# Altium schematic sheet E08-GN02.SchDoc
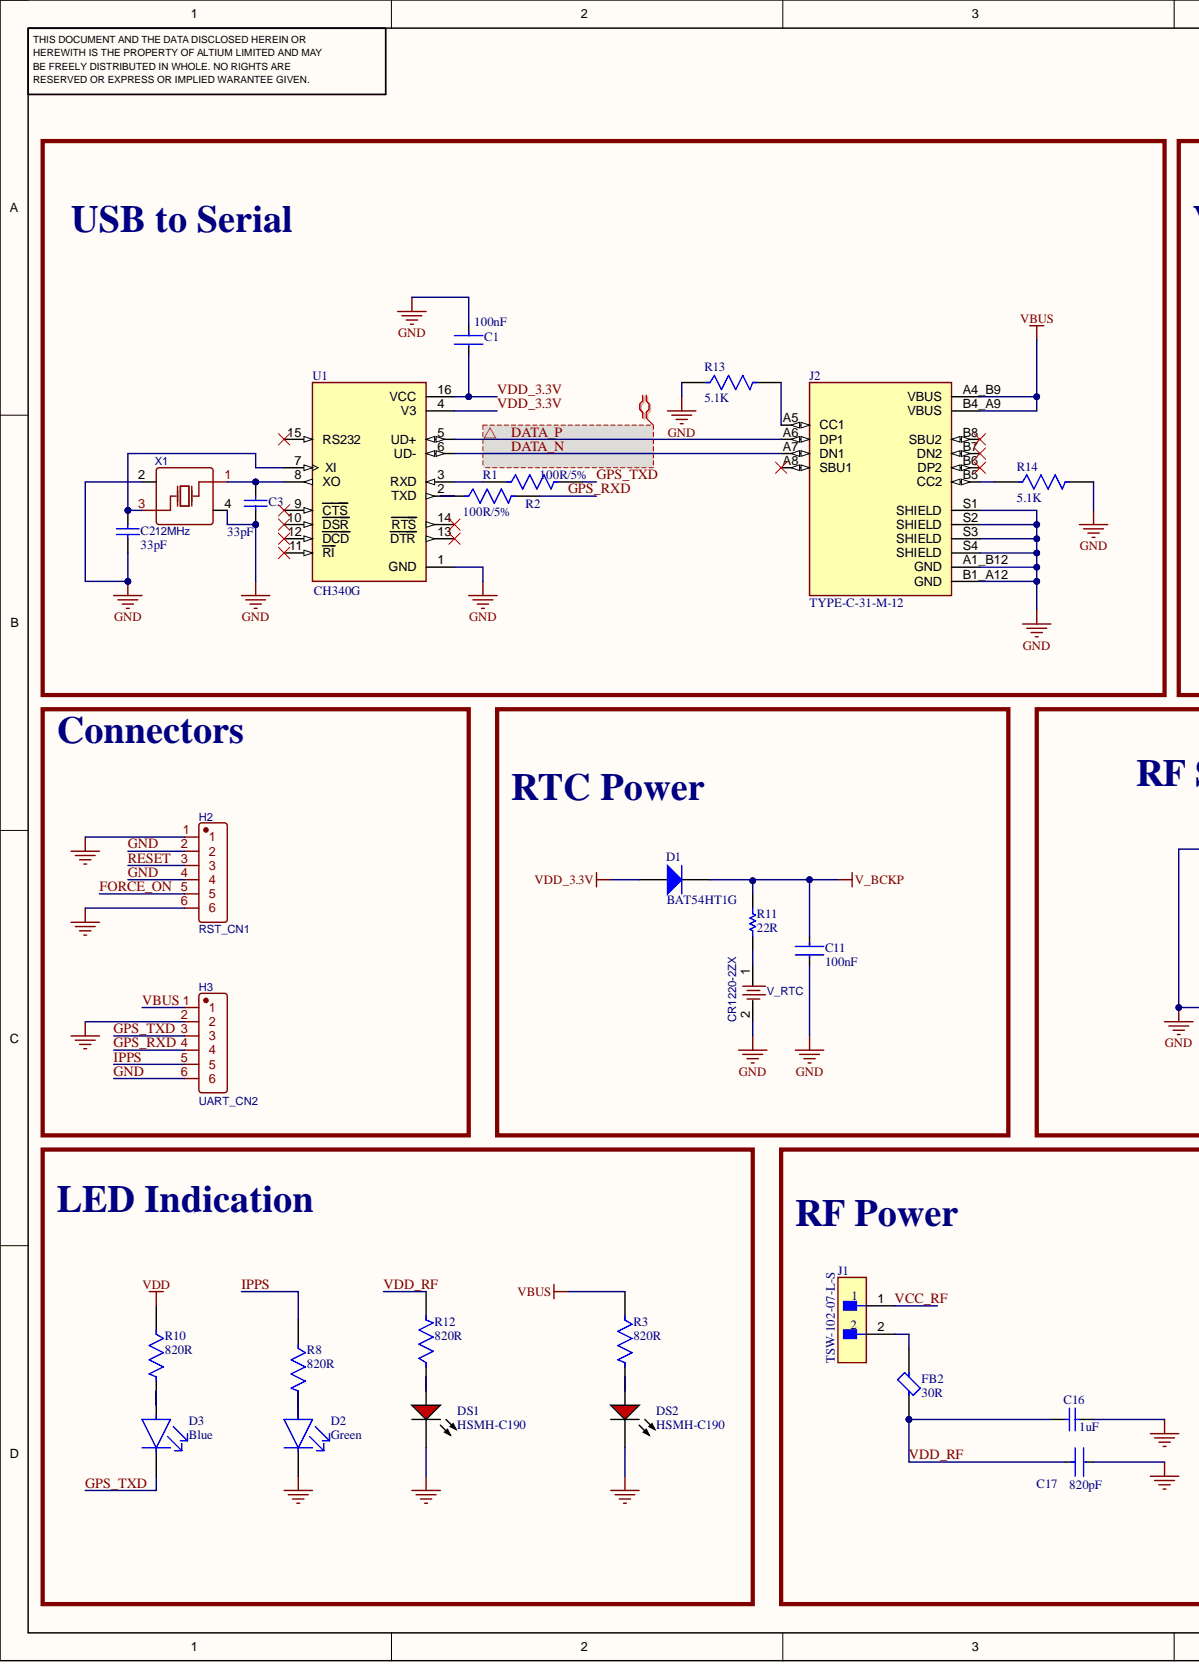
[diagram p0: E08-GN02.SchDoc, left]
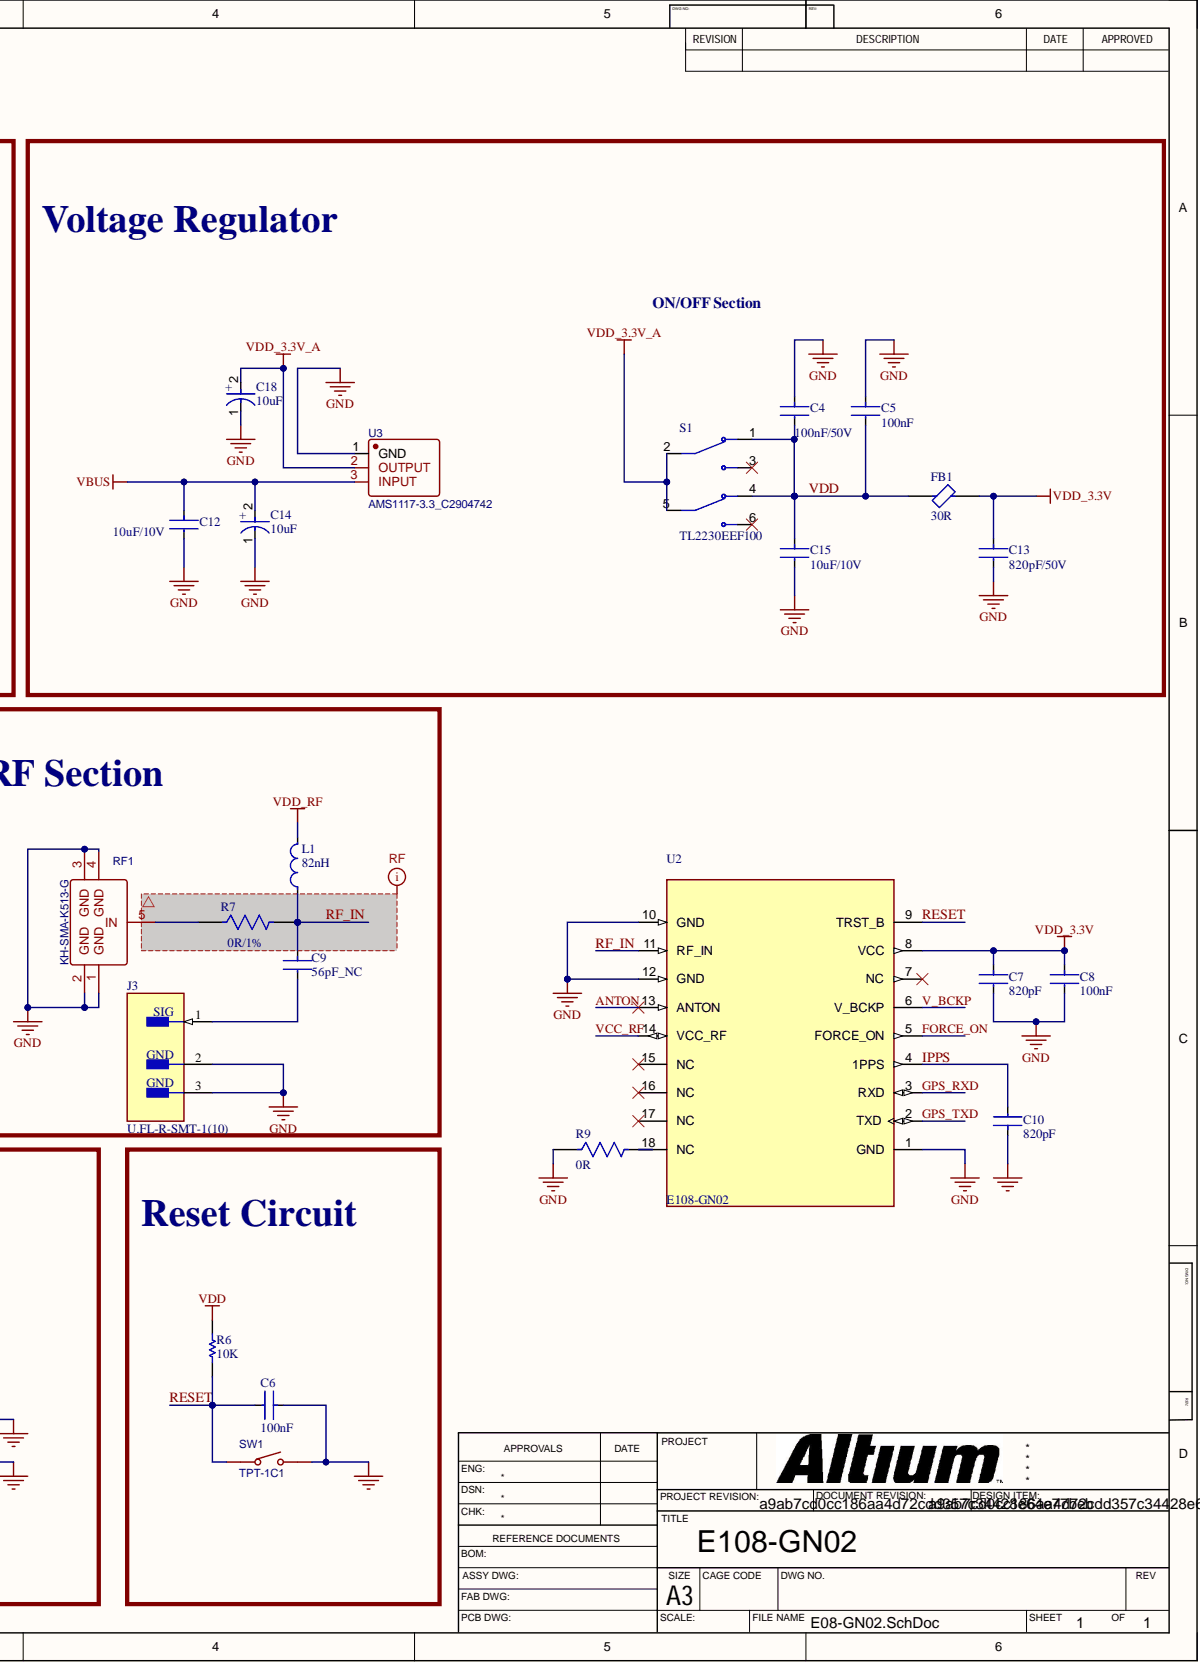
[diagram p1: E08-GN02.SchDoc, right]

## Parts
C1  100nF  [FP-CGA3E-0_3-0_1-IPC_A]
C2  33pF  [CAPC1608X92X38ML15T08]
C3  33pF  [CAPC1608X92X38ML15T08]
C4  100nF/50V  [FP-CGA3E-0_3-0_1-IPC_A]
C5  100nF  [FP-CGA3E-0_3-0_1-IPC_A]
C6  100nF  [FP-CGA3E-0_3-0_1-IPC_A]
C7  820pF  [FP-C0805C-DN-MFG]
C8  100nF  [FP-CGA3E-0_3-0_1-IPC_A]
C9  56pF_NC  [FP-C0805C-DN-MFG]
C10  820pF  [FP-C0805C-DN-MFG]
C11  100nF  [FP-C0805C-DN-MFG]
C12  10uF/10V  [FP-C0805C-DG-MFG]
C13  820pF/50V  [FP-C0805C-DN-MFG]
C14  10uF  [FP-TAJA-MFG]
C15  10uF/10V  [FP-C0805C-DG-MFG]
C16  1uF  [CAPC2013X135X45LL10T25]
C17  820pF  [FP-C0805C-DN-MFG]
C18  10uF  [FP-TAJA-MFG]
D1  BAT54HT1G  [ONSC-SOD-323-2-477-02_V]
D2  Green  [FP-LTST-C190GKT-MFG]
D3  Blue  [FP-LTST-C191TBKT-MFG]
DS1  HSMH-C190  [AVAG-HSMX-C190_V]
DS2  HSMH-C190  [AVAG-HSMX-C190_V]
FB1  30R  [FP-MMZ1608-0_8-IPC_A]
FB2  30R  [FP-MMZ1608-0_8-IPC_A]
H2  RST_CN1  [HDR-TH_6P-P2.54-V-M]
H3  UART_CN2  [HDR-TH_6P-P2.54-V-M]
J1  TSW-102-07-L-S  [FP-TSW-102-07-L-S-MFG]
J2  TYPE-C-31-M-12  [HRO_TYPE-C-31-M-12]
J3  U.FL-R-SMT-1(10)  [FP-U_FL-R-SMT-1_10-MFG]
L1  82nH  [FP-LQW18AN_00-MFG]
R1  100R/5%  [FP-CRCW0603-e3-IPC_B]
R2  100R/5%  [FP-CRCW0603-e3-IPC_B]
R3  820R  [FP-CRCW0805-e3-IPC_B]
R6  10K  [RESC2013X60X35NL10T20]
R7  0R/1%  [FP-RC0603-MFG]
R8  820R  [FP-CRCW0805-e3-IPC_B]
R9  0R  [FP-CRCW0805-e3-IPC_B]
R10  820R  [FP-CRCW0805-e3-IPC_B]
R11  22R  [RESC2013X70X40LL20T20]
R12  820R  [FP-CRCW0805-e3-IPC_B]
R13  5.1K  [FP-CRCW0603-e3-IPC_C]
R14  5.1K  [FP-CRCW0603-e3-IPC_C]
RF1  KH-SMA-K513-G  [ANT-TH_KH-SMA-K513-G]
S1  TL2230EEF100  [SW_TL2230EEF100]
SW1  TPT-1C1  [KEY-SMD_L3.0-W2.0-LS3.5]
U1  CH340G  [SOIC127P600X180-16N]
U2  E108-GN02  [E108-GN02]
U3  AMS1117-3.3_C2904742  [SOT-89-3_L4.5-W2.5-P1.50-LS4.1-BL]
V_RTC  CR1220-2ZX  [BAT-SMD_CR1220-2ZX]
X1  12MHz  [OSC-SMD_4P-L3.2-W2.5-BL]

## Nets
VDD_3.3V_A: C18.2 S1.2 S1.5 U3.2
ANTON: U2.13(ANTON)
DATA_N: J2.A7(DN1) U1.6(UD-)
DATA_P: J2.A6(DP1) U1.5(UD+)
FORCE_ON: H2.5 U2.5(FORCE_ON)
GND: C1.1 C2.1 C3.1 C4.1 C5.1 C6.1 C7.2 C8.2 C10.1 C11.2 C12.2 C13.2 C14.1 C15.2 C16.2 C17.2 C18.1 D2.1 DS1.2 DS2.2 H2.1 H2.2 H2.4 H2.6 H3.2 H3.6 J2.A1_B12(GND) J2.B1_A12(GND) J2.S1(SHIELD) J2.S2(SHIELD) J2.S3(SHIELD) J2.S4(SHIELD) J3.2 J3.3 R9.1 R13.1 R14.2 RF1.1(GND) RF1.2(GND) RF1.3(GND) RF1.4(GND) SW1.2 U1.1(GND) U2.1(GND) U2.10(GND) U2.12(GND) U3.1 V_RTC.2 X1.2 X1.4
GPS_RXD: H3.4 R2.2 U2.3(RXD)
GPS_TXD: D3.1 H3.3 R1.2 U2.2(TXD)
IPPS: C10.2 H3.5 R8.1 U2.4(1PPS)
RESET: C6.2 H2.3 R6.2 SW1.1 U2.9(TRST_B)
RF_IN: C9.1 L1.1 R7.2 U2.11(RF_IN)
V_BCKP: C11.1 D1.1 R11.2 U2.6(V_BCKP)
VBUS: C12.1 C14.2 H3.1 J2.A4_B9(VBUS) J2.B4_A9(VBUS) R3.1 U3.3
VCC_RF: J1.1 U2.14(VCC_RF)
VDD: C4.2 C5.2 C15.1 FB1.1 R6.1 R10.1 S1.1 S1.4
VDD_3.3V: C1.2 C7.1 C8.1 C13.1 D1.2 FB1.2 U1.4(V3) U1.16(VCC) U2.8(VCC)
VDD_RF: C16.1 C17.1 FB2.2 L1.2 R12.2
NetC2_2: C2.2 U1.7(XI) X1.3
NetC3_2: C3.2 U1.8(XO) X1.1
NetC9_2: C9.2 J3.1
NetD2_2: D2.2 R8.2
NetD3_2: D3.2 R10.2
NetDS1_1: DS1.1 R12.1
NetDS2_1: DS2.1 R3.2
NetFB2_1: FB2.1 J1.2
NetJ2_A5: J2.A5(CC1) R13.2
NetJ2_B5: J2.B5(CC2) R14.1
NetR1_1: R1.1 U1.3(RXD)
NetR2_1: R2.1 U1.2(TXD)
NetR7_1: R7.1 RF1.5(IN)
NetR9_2: R9.2 U2.18(NC)
NetR11_1: R11.1 V_RTC.1

Not connected: J2 A8(SBU1) B6(DP2) B7(DN2) B8(SBU2); S1 3 6; U1 9(CTS) 10(DSR) 11(RI) 12(DCD) 13(DTR) 14(RTS) 15(RS232); U2 7(NC) 15(NC) 16(NC) 17(NC)

## Library data of the parts
C1 C4-C6 C8 = CMP-2000-07546-2: Cap Ceramic 100nF 50V X7R 10% Pad SMD 0603 +125°C Automotive T/R; Manufacturer: YAGEO; Manufacturer Part Number: CC0603KRX7R9BB104; Capacitance: 100nF; Case Code (Imperial): 0603; Case Code (Metric): 1608; Case/Package: 0603; Component Kind: Standard; Composition: Ceramic; Depth: 0.8mm; Dielectric: X7R; Height: 0.8mm; LCSC Part: C14663; Lead Free: Lead Free; Length: 1.6mm; Library Name: Altium Content Vault; Max Operating Temperature: 125°C; Min Operating Temperature: -55°C; Mount: Surface Mount; Package Quantity: 1; Packaging: Tape and Reel; Radiation Hardening: No; Ratings: AEC-Q200; REACH SVHC: No SVHC; Termination: SMD/SMT; Thickness: 0.035inch; Tolerance: 10%; Voltage: 50V; Voltage Rating: 50V; Voltage Rating (DC): 50V; Weight: 7.1E-05oz; Width: 0.8mm
C2 C3 = CMP-2006-01513-1: Manufacturer: Samsung Electro-Mechanics; Manufacturer Part Number: CL10C330JB8NNNC; Part Number: VJ0603D330JXPAJ; Component Kind: Standard; LCSC Part: C1663; Library Name: Altium Content Vault
C7 C10 C13 C17 = CMP-2007-00457-2: CAP CER 820PF 50V 5% NP0 0805; Manufacturer: YAGEO; Manufacturer Part Number: CC0805KRX7R0BB821; Material: Ceramic; Temperature Characteristic: C0G; Temperature Coefficient: 30ppm/°C; Tolerance: 0.05; Automotive: No; Capacitance: 820 pF; Category: Cap; Component Kind: Standard; ComponentLink2Description: Datasheet; ComponentLink2URL: https://content.kemet.com/datasheets/KEM_C1003_C0G_SMD.pdf; Device Class L1: Passive Components; Device Class L2: Capacitors; Device Class L3: Ceramic Capacitors; Digikey Description: CAP CER 820PF 50V 5% NP0 0805; Height: 0.88mm; Ipc Land Pattern Name: CAPC200125X78; LCSC Part: C577015; Lead Free: Yes; Library Name: Altium Content Vault; Mouser Description: Multilayer Ceramic Capacitors MLCC - SMD/SMT 50volts 820pF C0G 5%; Octopart: https://octopart.com/c0805c821j5gactu-kemet-3873926; Package: C0805C-DN; RoHS: TRUE; Temperature: -55°C to +125°C; Temperature Range High: +125°C; Temperature Range Low: -55°C; Value: 820 pF; Voltage Rating: 50 V
C9 = CMP-2007-00050-2: CAP CER 56PF 50V C0G/NP0 0805; Manufacturer: YAGEO; Manufacturer Part Number: CC0805FRNPO9BN560; Material: Ceramic; Temperature Characteristic: C0G; Temperature Coefficient: 30ppm/°C; Tolerance: 0.05; Automotive: No; Capacitance: 56 pF; Category: Cap; Component Kind: Standard; ComponentLink2Description: Datasheet; ComponentLink2URL: https://content.kemet.com/datasheets/KEM_C1003_C0G_SMD.pdf; Device Class L1: Passive Components; Device Class L2: Capacitors; Device Class L3: Ceramic Capacitors; Digikey Description: CAP CER 56PF 50V C0G/NP0 0805; Height: 0.88mm; Ipc Land Pattern Name: CAPC200125X78; LCSC Part: NC-C527187; Lead Free: Yes; Library Name: Altium Content Vault; Mouser Description: 0805 C 56pF Ceramic Multilayer Capacitor, 50 VDC, +125degC, C0G Dielec, +/-5%; Octopart: https://octopart.com/c0805c560j5gactu-kemet-93783; Package: C0805C-DN; RoHS: TRUE; Temperature: -55°C to +125°C; Temperature Range High: +125°C; Temperature Range Low: -55°C; Value: 56 pF; Voltage Rating: 50 V
C11 = CMP-2007-00279-2: CAP CER 0.1UF 50V X7R 0805; Manufacturer: YAGEO; Manufacturer Part Number: CC0805KRX7R9BB104; Capacitance: 0.10 μF; Material: Ceramic; Temperature Coefficient: 30ppm/°C; Tolerance: 0.1; Value: 0.10 μF; Automotive: No; Category: Cap; Component Kind: Standard; ComponentLink2Description: Datasheet; ComponentLink2URL: https://content.kemet.com/datasheets/KEM_C1003_C0G_SMD.pdf; Device Class L1: Passive Components; Device Class L2: Capacitors; Device Class L3: Ceramic Capacitors; Digikey Description: CAP CER 0.1UF 50V X7R 0805; Height: 0.88mm; Ipc Land Pattern Name: CAPC200125X78; LCSC Part: C49678; Lead Free: Yes; Library Name: Altium Content Vault; Mouser Description: Cap Ceramic 0.1uF 50V X7R 10% SMD 2.0 x 1.2 mm 125 C Paper T/R; Octopart: https://octopart.com/c0805c104k5ractu-kemet-143926; Package: C0805C-DN; RoHS: TRUE; Temperature: -55°C to +125°C; Temperature Characteristic: X7R; Temperature Range High: +125°C; Temperature Range Low: -55°C; Voltage Rating: 50 V
C12 C15 = CMP-2007-03243-2: CAP CER 10UF 10V X5R 0805; 3DS Part Number: 220-00001; Manufacturer: YAGEO; Manufacturer Part Number: CC0805KKX5R6BB106; Material: Ceramic; Temperature Coefficient: 15%; Tolerance: 10%; Automotive: No; Capacitance: 10uF; Category: Cap; Component Kind: Standard; ComponentLink2Description: Datasheet; ComponentLink2URL: https://content.kemet.com/datasheets/KEM_C1006_X5R_SMD.pdf; Device Class L1: Passive Components; Device Class L2: Capacitors; Device Class L3: Ceramic Capacitors; Digikey Description: CAP CER 10UF 10V X5R 0805; Height: 1.4mm; Ipc Land Pattern Name: CAPC200125X125; LCSC Part: C89832; Lead Free: Yes; Library Name: Altium Content Vault; Mouser Description: CAP CER 10UF 10V X5R 0805; Octopart: https://octopart.com/c0805c106k8pactu-kemet-98697; Package: C0805C-DG; RoHS: TRUE; Temperature: -55°C to +85°C; Temperature Characteristic: X5R; Temperature Range High: +85°C; Temperature Range Low: -55°C; Value: 10uF; Voltage: 10V; Voltage Rating: 10V
C14 C18 = CMP-2000-07217-2: 10uF 10V  ±10% 3Ω 1206  SMD Tantalum Capacitor; Dissipation Factor: 6%; ESR (Equivalent Series Resistance): 3R; Leakage Current: 1uA; Polarity: Polar; Q Factor: 6; Capacitance: 10uF; Case Code (Imperial): 1206; Case Code (Metric): 3216; Case/Package: 1206; Component Kind: Standard; Construction: Flat; Depth: 1.6mm; Dielectric Material: Tantalum; Features: General Purpose; Height: 1.6mm; Height - Seated (Max): 0.071inch; LCSC Part: C7171; Lead Free: Lead Free; Length: 3.2mm; Library Name: Altium Content Vault; Max Operating Temperature: 125°C; Min Operating Temperature: -55°C; Mount: Surface Mount; Number of Pins: 2; Package Quantity: 1; Packaging: Tape and Reel; Radiation Hardening: No; REACH SVHC: No SVHC; RoHS Compliant: Yes; Series: TAJ; Termination: SMD/SMT; Tolerance: 10%; Voltage: 10V; Voltage Rating: 10V; Voltage Rating (DC): 10V; Width: 1.6mm
C16 = CMP-1036-04761-2: Manufacturer: Samsung Electro-Mechanics; Manufacturer Part Number: CL21B105KBFNNNE; Part Number: GRM21BR71H105KA12L; Component Kind: Standard; LCSC Part: C28323; Library Name: Altium Content Vault
D1 = CMP-1055-00462-1: Schottky Barrier Diode, 2-Pin SOD-323, Pb-Free, Tape and Reel; Manufacturer: onsemi; Configuration: Single; IFSM Max (A): 0.6; trr Max (ns): 5; Component Kind: Standard; ComponentLink1Description: Manufacturer URL; ComponentLink1URL: http://www.onsemi.com/; ComponentLink2Description: Datasheet; ComponentLink2URL: http://www.onsemi.cn/pub_link/Collateral/BAT54HT1-D.PDF; ComponentLink3Description: Package Specification; ComponentLink3URL: http://www.onsemi.com/pub_link/Collateral/477-02.PDF.1; DatasheetVersion: Rev. 6; LCSC Part: C21107; Library Name: Altium Content Vault; Manufacturer Part Number: BAT54HT1G; Mounting Technology: Surface Mount; PackageDescription: 2-Pin SOD, Body 1.7 x 1.25 mm; PackageReference: SOD-323-2-477-02; PackageVersion: Rev. H, 03/2007; Packing: Tape and Reel; PartNumber: BAT54HT1G; RoHS: TRUE
D2 = CMP-22017-000005-2: LED GREEN CLEAR CHIP SMD; Manufacturer: Everlight Elec; Manufacturer Part Number: 19-217/GHC-YR1S2/3T; Dominant Wavelength: 569nm; Forward Current: 30mA; Forward Voltage: 2.1V; Lens Color: Colorless; Luminous Intensity: 6mcd; Number of Elements: 1; Power Dissipation: 100mW; Reverse Voltage: 5V; Test Current: 10mA; Viewing Angle: 130°; Voltage: 2V; Wavelength: 565nm; Case Code (Imperial): 0603; Case Code (Metric): 1608; Case/Package: 0603; Color: Green; Component Kind: Standard; Depth: 0.8mm; Height: 0.8mm; Illumination Color: Green; LCSC Part: C72043; Lead Free: Lead Free; Length: 1.6mm; Lens Style: Clear; Lens Transparency: Clear; Library Name: Altium Content Vault; Max Operating Temperature: 85°C; Min Operating Temperature: -55°C; Mount: Surface Mount; Number of LEDs: 1; Number of Pins: 2; Package Quantity: 1; Packaging: Tape and Reel; Power Rating: 100mW; Radiation Hardening: No; Width: 0.8mm
D3 = CMP-22017-000008-1: LED BLUE CLEAR CHIP SMD; Manufacturer Part Number: Everlight Elec; Dominant Wavelength: 475nm; Forward Current: 20mA; Forward Voltage: 3.8V; Lens Color: Colorless; Lens Style: Diffused; Luminous Intensity: 180mcd; Max Power Dissipation: 75mW; Number of Elements: 1; Power Dissipation: 76mW; Reverse Voltage: 5V; Viewing Angle: 130°; Wavelength: 468nm; Case Code (Imperial): 0603; Case Code (Metric): 1608; Case/Package: 0603; Color: Blue; Component Kind: Standard; Depth: 1.6mm; Height: 0.55mm; Illumination Color: Blue; LCSC Part: C72041; Lead Free: Lead Free; Length: 0.8mm; Lens Transparency: Clear; Library Name: Altium Content Vault; Max Operating Temperature: 80°C; Min Operating Temperature: -20°C; Mount: Surface Mount; Number of LEDs: 1; Number of Pins: 2; Package Quantity: 1; Packaging: Tape and Reel; Radiation Hardening: No; Test Current: 20mA; Width: 0.8mm
DS1 = CMP-1744-00004-1: LED Uni-Color Red, 65 mW, 20 mA, -40 to 85 degC, 2-Pin SMD, RoHS, Tape and Reel; Manufacturer: Hubei KENTO Elec; Manufacturer Part Number: KT-0603R; Connector Type: USB; Current Rating: 1 A; Gender: Male; Component Kind: Standard; ComponentLink1Description: Manufacturer URL; ComponentLink1URL: http://www.avagotech.com/; ComponentLink2Description: Datasheet; ComponentLink2URL: http://docs.avagotech.com/docs/AV02-0551EN; ComponentLink3Description: Package Specification; ComponentLink3URL: http://www.fairchildsemi.com/dwg/MA/MA03D.pdf; Contact Material: Copper; Contact Plating: Gold; DatasheetVersion: Mar-12; Height: 2.8 mm; LCSC Part: C2286; Lead Pitch: 0.65 mm; Length: 7.5 mm; Library Name: Altium Content Vault; Max Operating Temperature: 85 degC; Min Operating Temperature: -30 degC; Mount: Surface Mount; Package Quantity: 2000; PackageDescription: 2-Pin Surface Mount Device, Body 1.6 x 0.8 mm, Height 0.9 mm; PackageReference: HSMX-C190; Packaging: Tape and Reel; Pins: 5; REACH SVHC: No SVHC; RoHSCompliant: true; Width: 5.6 mm
DS2 = CMP-1744-00004-1: LED Uni-Color Red, 65 mW, 20 mA, -40 to 85 degC, 2-Pin SMD, RoHS, Tape and Reel; Connector Type: USB; Current Rating: 1 A; Gender: Male; Component Kind: Standard; ComponentLink1Description: Manufacturer URL; ComponentLink1URL: http://www.avagotech.com/; ComponentLink2Description: Datasheet; ComponentLink2URL: http://docs.avagotech.com/docs/AV02-0551EN; ComponentLink3Description: Package Specification; ComponentLink3URL: http://www.fairchildsemi.com/dwg/MA/MA03D.pdf; Contact Material: Copper; Contact Plating: Gold; DatasheetVersion: Mar-12; Height: 2.8 mm; Lead Pitch: 0.65 mm; Length: 7.5 mm; Library Name: Altium Content Vault; Max Operating Temperature: 85 degC; Min Operating Temperature: -30 degC; Mount: Surface Mount; Package Quantity: 2000; PackageDescription: 2-Pin Surface Mount Device, Body 1.6 x 0.8 mm, Height 0.9 mm; PackageReference: HSMX-C190; Packaging: Tape and Reel; Pins: 5; REACH SVHC: No SVHC; RoHSCompliant: true; Width: 5.6 mm
FB1 FB2 = CMP-08257-000413-1: Signal Line Ferrite Bead 30Ω  @ 100MHz 1500mA DCR 50mΩ SMD 0603; Manufacturer: TAI-TECH; Manufacturer Part Number: HCB1608KF-600T30; Current Rating: 1.5A; DC Resistance (DCR): 50mR; Tolerance: 25%; Case Code (Imperial): 0603; Case Code (Metric): 1608; Case/Package: 0603; Component Kind: Standard; Core Material: Ferrite; Height: 0.037inch; Impedance: 30R; LCSC Part: C304317; Lead Free: Lead Free; Length: 0.063inch; Library Name: Altium Content Vault; Max DC Current: 1.5A; Mount: Surface Mount; Package Quantity: 1; Packaging: Cut Tape; REACH SVHC: No SVHC; RoHS Compliant: Yes; Series: MMZ; Width: 0.031inch
H2 H3 = KH-A2541WV-6P: BOM_Manufacturer: kinghelm(金航标); Contributor: LCSC; BOM_JLCPCB Part Class: Extended Part; BOM_Manufacturer Part: KH-A2541WV-6P; BOM_Supplier: LCSC; BOM_Supplier Part: C2833331; Component Kind: Standard; Library Name: E108-GN02.SchLib; spicePre: H; spiceSymbolName: KH-A2541WV-6P
J1 = CMP-02766-001428-1: CONN HEADER VERT 2POS 2.54MM; Manufacturer: ZHOURI; Manufacturer Part Number: 2.54-1*2; Connector Type: Male Pin; Current Rating: 7.6A; Gender: Male; Max Voltage Rating (AC): 550V; Number of Contacts: 2; Color: Black; Component Kind: Standard; Contact Material: Bronze; Contact Mating Length: 0.23inch; Contact Plating: Gold; Contact Type: Male Pin; Depth: 2.54mm; Housing Color: Black; Housing Material: Polyester; LCSC Part: NC-C5116481; Lead Free: Lead Free; Length: 5.08mm; Library Name: Altium Content Vault; Max Current Rating: 7.6A; Max Operating Temperature: 125°C; Min Operating Temperature: -55°C; Mount: Through Hole; Number of Positions: 2; Number of Rows: 1; Orientation: Straight; Package Quantity: 1; Packaging: Bulk; Pitch: 2.54mm; Radiation Hardening: No; REACH SVHC: No SVHC; RoHS Compliant: Yes; Series: TSW; Termination: Solder; Voltage Rating: 550V; Width: 2.54mm
J2 = TYPE-C-31-M-12: Manufacturer: Korean Hroparts Elec; MF: {'manufacturer': u'HRO Electronics Co., Ltd.'}; Availability: Unavailable; Component Kind: Standard; LCSC Part: C165948; Manufacturer Part Number: TYPE-C-31-M-12; MP: TYPE-C-31-M-12; Package: None; Price: None
J3 = CMP-2000-05152-3: CONN U.FL RCPT STR 50 OHM SMD; Manufacturer: HRS(Hirose); Body Material: Bronze; Body Plating: Silver; Connector Type: Coaxial; Dielectric Material: Polymer; Frequency: 6GHz; Gender: Male; Impedance: 50R; Insulation Resistance: 500MR; Component Kind: Standard; Contact Material: Brass; Contact Plating: Gold; Depth: 3.1mm; Fastening Type: Snap; Housing Color: Beige; LCSC Part: C88373; Lead Free: Lead Free; Length: 3mm; Library Name: Altium Content Vault; Manufacturer Part Number: U.FL-R-SMT-1(10); Max Frequency: 6GHz; Max Operating Temperature: 90°C; Min Operating Temperature: -40°C; Mount: Surface Mount; Orientation: Straight; Package Quantity: 2500; Packaging: Cut Tape; Radiation Hardening: No; REACH SVHC: No SVHC; Series: U.FL; Termination: Solder; Weight: 0.001764oz; Wire/Cable Type: Coaxial
L1 = CMP-06042-011367-1: Wire Wound RF Inductor 82nH ±2% 250mA 0.6Ω 0603 (1608); Manufacturer: Sunltech Tech; Manufacturer Part Number: SCC1608C82NJSP; Core Material: Non-Magnetic; Current: 550mA; DC Resistance (DCR): 600mR; Q Factor: 34; Self Resonant Frequency: 2GHz; Shielding: Unshielded; Test Frequency: 100MHz; Case Code (Imperial): 0603; Case Code (Metric): 1608; Case/Package: 0603; Component Kind: Standard; Current Rating: 250mA; DC Current: 250mA; Depth: 1mm; Height: 0.8mm; Height - Seated (Max): 0.039inch; Inductance: 82nH; Inductance Tolerance: 2%; LCSC Part: C412043; Lead Free: Lead Free; Length: 1.6mm; Library Name: Altium Content Vault; Max DC Current: 250mA; Max Operating Temperature: 125°C; Military Standard: Not; Min Operating Temperature: -55°C; Mount: Surface Mount; Package Quantity: 4000; Packaging: Tape and Reel; REACH SVHC: No SVHC; Resistor Type: Wirewound; Series Resistance: 600mR; Termination: SMD/SMT; Tolerance: 2%; Weight: 0.000106oz; Width: 0.8mm
R1 R2 = CMP-2000-03827-2: RES Thick Film, 100Ω, 5%, 0.1W, 200ppm/°C, 0603; Manufacturer: FOJAN; Manufacturer Part Number: FRC0603J101 TS; Max Power Dissipation: 100mW; Resistance: 100R; Voltage Rating: 75V; Case Code (Imperial): 0603; Case Code (Metric): 1608; Case/Package: 0603; Component Kind: Standard; Composition: Thick Film; Contact Plating: Tin; Depth: 0.85mm; Features: Automotive AEC-Q200; Height: 0.02inch; LCSC Part: C2907089; Length: 1.55mm; Library Name: Altium Content Vault; Max Operating Temperature: 155°C; Military Standard: Not; Min Operating Temperature: -55°C; Number of Terminations: 2; Package Quantity: 1; Packaging: Tape and Reel; Power Rating: 100mW; Radiation Hardening: No; Temperature Coefficient: 200ppm/°C; Termination: SMD/SMT; Tolerance: 5%; Weight: 7.1E-05oz; Width: 0.85mm
R3 R10 R12 = CMP-2001-04130-2: RES Thick Film, 820Ω, 1%, 0.125W, 100ppm/°C, 0805; Manufacturer: UNI-ROYAL(Uniroyal Elec); Manufacturer Part Number: 0805W8F8200T5E; Max Power Dissipation: 125mW; Voltage Rating: 150V; Case Code (Imperial): 0805; Case Code (Metric): 2012; Case/Package: 0805; Component Kind: Standard; Composition: Thick Film; Contact Plating: Tin; Depth: 1.25mm; Features: Automotive AEC-Q200; Height: 0.45mm; LCSC Part: C17837; Lead Free: Lead Free; Length: 2mm; Library Name: Altium Content Vault; Max Operating Temperature: 155°C; Military Standard: Not; Min Operating Temperature: -55°C; Number of Terminations: 2; Package Quantity: 10; Packaging: Tape and Reel; Power Rating: 125mW; Radiation Hardening: No; Resistance: 820R; Temperature Coefficient: 100ppm/°C; Termination: SMD/SMT; Tolerance: 1%; Voltage Rating (DC): 150V; Weight: 0.000194oz; Width: 1.25mm
R6 = CMP-1659-00013-4: Chip Resistor, 10 KOhm, +/- 1%, 0.125 W, -55 to 155 degC, 0805 (2012 Metric), RoHS, Tape and Reel; Manufacturer: UNI-ROYAL(Uniroyal Elec); Manufacturer Part Number: 0805W8F1002T5E; Voltage Rating: 150 V; Component Kind: Standard; ComponentLink1Description: Manufacturer URL; ComponentLink1URL: http://www.yageo.com/; ComponentLink2Description: Datasheet; ComponentLink2URL: http://www.yageo.com/documents/recent/PYu-RC_Group_51_RoHS_L_04.pdf; DatasheetVersion: Rev. 4, 02/2016; Height: 0.5 mm; LCSC Part: C17414; Length: 2 mm; Library Name: Altium Content Vault; Max Operating Temperature: 155 degC; Min Operating Temperature: -55 degC; Mount: Surface Mount; Mounting Technology: Surface Mount; Package Quantity: 5000; PackageDescription: 2-Pin Surface Mounted Device, Body 2 x 1.25 mm; PackageReference: 0805; Packaging: Tape and Reel; Pins: 2; REACH SVHC: No SVHC; RoHSCompliant: true
R7 = CMP-2000-06925-2: RES SMD 0 OHM JUMPER 1/10W 0603; Manufacturer: UNI-ROYAL(Uniroyal Elec); Manufacturer Part Number: 0603WAF0000T5E; Power Rating: 0.1W; Resistance: 0Ω; Tolerance: 1%; Value: 0Ω; Voltage Rating: 75V; Automotive: No; Category: Res; Component Kind: Standard; ComponentLink2Description: Datasheet; ComponentLink2URL: http://www.yageo.com/documents/recent/PYu-RC_Group_51_RoHS_L_10.pdf; Composition: Thick Film; Device Class L1: Passive Components; Device Class L2: Resistors; Device Class L3: Chip SMD Resistors; Digikey Description: RES SMD 0 OHM JUMPER 1/10W 0603; Footprint Url: http://www.yageo.com/exep/pages/download/literatures/PYu-R_Mount_10.pdf; Height: 0.55mm; Ipc Land Pattern Name: RESC16080X45; LCSC Part: C21189; Lead Free: Yes; Library Name: Altium Content Vault; Mouser Description: RC Series 0603 0.1 W 0 O Jumper Surface Mount Thick Film Chip Resistor; Octopart: https://octopart.com/rc0603fr-070rl-yageo-1180803; Package: RC0603; RoHS: TRUE; Series: RC_L; Temperature: -55°C to +155°C; Temperature Range High: +155°C; Temperature Range Low: -55°C
R8 = CMP-2001-04130-2: RES Thick Film, 820Ω, 1%, 0.125W, 100ppm/°C, 0805; Manufacturer: Everlight Elec; Manufacturer Part Number: 0805W8F8200T5E; Max Power Dissipation: 125mW; Voltage Rating: 150V; Case Code (Imperial): 0805; Case Code (Metric): 2012; Case/Package: 0805; Component Kind: Standard; Composition: Thick Film; Contact Plating: Tin; Depth: 1.25mm; Features: Automotive AEC-Q200; Height: 0.45mm; LCSC Part: C17837; Lead Free: Lead Free; Length: 2mm; Library Name: Altium Content Vault; Max Operating Temperature: 155°C; Military Standard: Not; Min Operating Temperature: -55°C; Number of Terminations: 2; Package Quantity: 10; Packaging: Tape and Reel; Power Rating: 125mW; Radiation Hardening: No; Resistance: 820R; Temperature Coefficient: 100ppm/°C; Termination: SMD/SMT; Tolerance: 1%; Voltage Rating (DC): 150V; Weight: 0.000194oz; Width: 1.25mm
R9 = CMP-2001-04897-2: RES Thick Film, 0Ω, 0.125W, 0805; Manufacturer: UNI-ROYAL(Uniroyal Elec); Manufacturer Part Number: 0805W8F0000T5E; Max Power Dissipation: 125mW; Resistance: 0mR; Voltage Rating: 150V; Case Code (Imperial): 0805; Case Code (Metric): 2012; Case/Package: 0805; Component Kind: Standard; Composition: Thick Film; Contact Plating: Tin; Depth: 1.25mm; Features: Automotive AEC-Q200; Height: 0.02inch; LCSC Part: C17477; Lead Free: Lead Free; Length: 2mm; Library Name: Altium Content Vault; Max Operating Temperature: 155°C; Military Standard: Not; Min Operating Temperature: -55°C; Number of Terminations: 2; Package Quantity: 10000; Packaging: Tape and Reel; Power Rating: 125mW; Radiation Hardening: No; Termination: SMD/SMT; Voltage Rating (DC): 150V; Weight: 0.000194oz; Width: 0.049inch
R11 = CMP-2001-00523-1: Manufacturer: UNI-ROYAL(Uniroyal Elec); Manufacturer Part Number: 0805W8F220JT5E; Part Number: ERJ6ENF22R0V; Component Kind: Standard; LCSC Part: C17561; Library Name: Altium Content Vault
R13 R14 = CMP-1012-00590-3: RES Thick Film, 5.1kΩ, 1%, 0.1W, 100ppm/°C, 0603; Manufacturer: UNI-ROYAL(Uniroyal Elec); Manufacturer Part Number: 0603WAF5101T5E; Power Rating: 100mW; Resistance: 5.1kR; Voltage Rating: 75V; Case Code (Imperial): 0603; Case Code (Metric): 1608; Case/Package: 0603; Component Kind: Standard; Composition: Thick Film; Contact Plating: Tin; Depth: 0.85mm; Height: 0.45mm; LCSC Part: C23186; Lead Free: Lead Free; Length: 1.55mm; Library Name: Altium Content Vault; Max Operating Temperature: 155°C; Military Standard: Not; Min Operating Temperature: -55°C; Mount: Surface Mount; Package Quantity: 5000; Packaging: Tape and Reel; Radiation Hardening: No; Temperature Coefficient: 100ppm/°C; Termination: SMD/SMT; Tolerance: 1%; Voltage Rating (DC): 75V; Weight: 7.1E-05oz; Width: 0.85mm
RF1 = KH-SMA-K513-G: BOM_Manufacturer: kinghelm(金航标); Contributor: LCSC; MAaufacturer: Shenzhen Kinghelm Elec; BOM_JLCPCB Part Class: Extended Part; BOM_Manufacturer Part: KH-SMA-K513-G; BOM_Supplier: LCSC; BOM_Supplier Part: C411575; Component Kind: Standard; LCSC Part: C411575; Library Name: E108-GN02.SchLib; Manufacturer Part Number: KH-SMA-K513-G; spicePre: R; spiceSymbolName: KH-SMA-K513-G
S1 = TL2230EEF100: Manufacturer: XKB Connectivity; Manufacturer Part Number: XKB5858-Z-75; MF: {'manufacturer': u'E-Switch'}; Availability: Unavailable; Component Kind: Standard; LCSC Part: C318867; MP: TL2230EEF100; Package: None; Price: None
SW1 = TPT-1C1: BOM_Manufacturer: ROCPU(台普); Manufacturer: ROCPU Switches; Contributor: LCSC; BOM_Manufacturer Part: TPT-1C1; BOM_Supplier: LCSC; BOM_Supplier Part: C2828562; Component Kind: Standard; LCSC Part: C2828562; Library Name: E108-GN02.SchLib; Manufacturer Part Number: TPT-1C1; spicePre: S; spiceSymbolName: TPT-1C1
U1 = CH340G: Manufacturer: WCH(Jiangsu Qin Heng); MF: {'manufacturer': u'WCH'}; Availability: Unavailable; Component Kind: Standard; LCSC Part: C14267; Manufacturer Part Number: CH340G; Package: None; Price: None
U2 = E108-GN02: E108-GN02 GPS Module; MF: {'manufacturer': u'Quectel'}; MP: LC79D; Availability: Unavailable; Component Kind: Standard; Library Name: GN02.SchLib; Package: None; Price: None
U3 = AMS1117-3.3_C2904742: BOM_Manufacturer: YONGYUTAI(永裕泰); Manufacturer: YONGYUTAI; Contributor: LCSC; BOM_JLCPCB Part Class: Extended Part; BOM_Manufacturer Part: AMS1117-3.3; BOM_Supplier: LCSC; BOM_Supplier Part: C2904742; Component Kind: Standard; LCSC Part: C2904742; Library Name: E108-GN02.SchLib; Manufacturer Part Number: AMS1117-3.3; spicePre: U; spiceSymbolName: AMS1117-3.3_C2904742
V_RTC = CR1220-2ZX: BOM_Manufacturer: Q&J; Contributor: LCSC; BOM_JLCPCB Part Class: Extended Part; BOM_Manufacturer Part: CR1220-2ZX; BOM_Supplier: LCSC; BOM_Supplier Part: C969906; Component Kind: Standard; Library Name: E108-GN02.SchLib; spicePre: B; spiceSymbolName: CR1220-2ZX
X1 = 12MHz: BOM_Manufacturer: YXC(扬兴晶振); BOM_Manufacturer Part: X322512MSB4SI; Manufacturer: Yangxing Tech; nameAlias: Frequency; BOM_JLCPCB Part Class: Basic Part; BOM_Supplier: LCSC; BOM_Supplier Part: C9002; Component Kind: Standard; LCSC Part: C9002; Library Name: E108-GN02.SchLib; Manufacturer Part Number: X322512MSB4SI; spicePre: X; spiceSymbolName: X322512MSB4SI
